# Altium schematic sheet Sheet3.SchDoc(Sheet3)
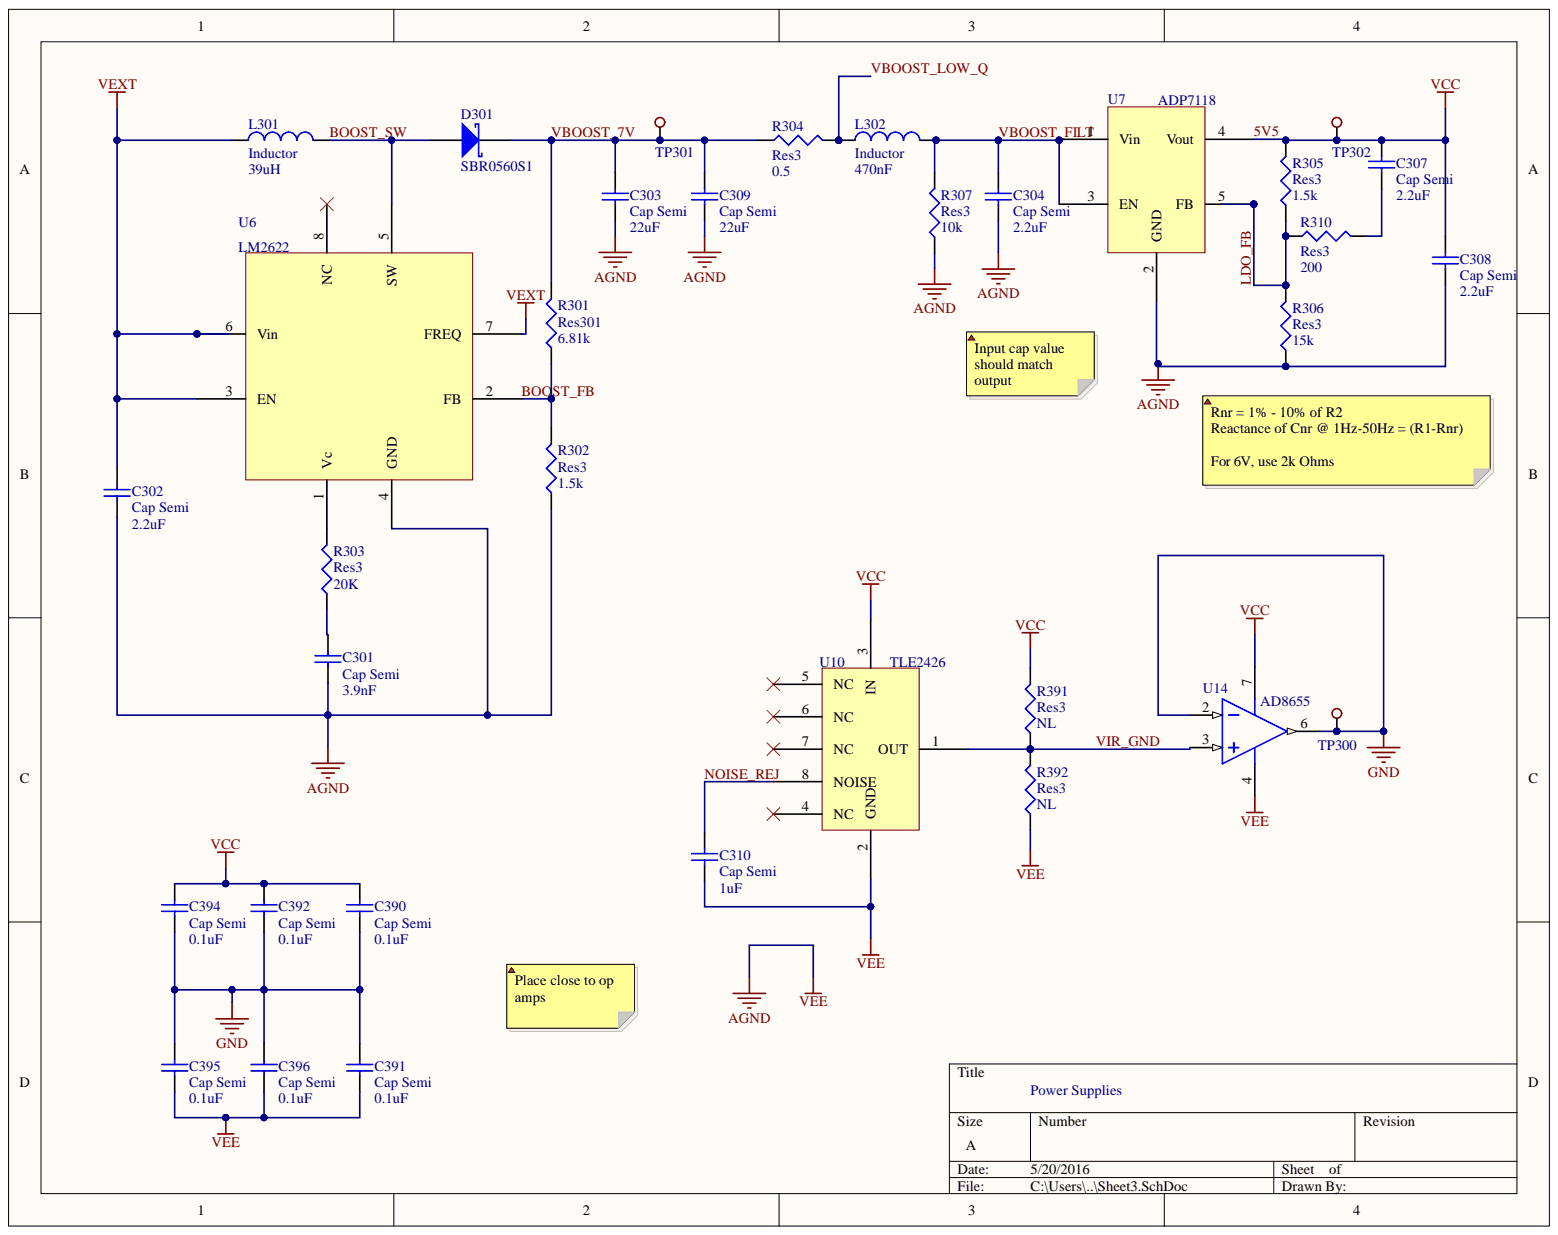
[diagram p0: Sheet3.SchDoc]

## Parts
C301  Cap Semi  value 3.9nF  [1608[0603]]
C302  Cap Semi  value 2.2uF  [1608[0603]]
C303  Cap Semi  value 22uF  [1608[0603]]
C304  Cap Semi  value 2.2uF  [1608[0603]]
C307  Cap Semi  value 2.2uF  [1608[0603]]
C308  Cap Semi  value 2.2uF  [1608[0603]]
C309  Cap Semi  value 22uF  [1608[0603]]
C310  Cap Semi  value 1uF  [1608[0603]]
C390  Cap Semi  value 0.1uF  [1608[0603]]
C391  Cap Semi  value 0.1uF  [1608[0603]]
C392  Cap Semi  value 0.1uF  [1608[0603]]
C394  Cap Semi  value 0.1uF  [1608[0603]]
C395  Cap Semi  value 0.1uF  [1608[0603]]
C396  Cap Semi  value 0.1uF  [1608[0603]]
D301  SBR0560S1  [SOD123]
L301  Inductor  value 39uH  [C1210_N]
L302  Inductor  value 470nF  [6-0805_M]
R301  Res301  value 6.81k  [J1-0603]
R302  Res3  value 1.5k  [J1-0603]
R303  Res3  value 20K  [J1-0603]
R304  Res3  value 0.5  [J1-0603]
R305  Res3  value 1.5k  [J1-0603]
R306  Res3  value 15k  [J1-0603]
R307  Res3  value 10k  [J1-0603]
R310  Res3  value 200  [J1-0603]
R391  Res3  value NL  [J1-0603]
R392  Res3  value NL  [J1-0603]
TP300  TEST_POINT  [TEST_POINT]  (value not drawn)
TP301  TEST_POINT  [TEST_POINT]  (value not drawn)
TP302  TEST_POINT  [TEST_POINT]  (value not drawn)
U6  LM2622  [VSSOP-8]
U7  ADP7118  [SOT23-5BN]
U10  TLE2426  [SO8_M]
U14  AD8655  [SO8_M]

## Nets
AGND: C301.1 C302.1 C303.1 C304.1 C308.1 C309.1 C310.1 C391.1 C395.1 C396.1 R302.1 R306.1 R307.1 R392.1 U6.4(GND) U7.2(GND) U10.2(GND) U14.4
GND: C390.1 C391.2 C392.1 C394.1 C395.2 C396.2 TP300.0 U14.2 U14.6
VEXT: C302.2 L301.1 U6.3(EN) U6.6(Vin) U6.7(FREQ)
5V5: C307.2 C308.2 C390.2 C392.2 C394.2 R305.2 R391.2 TP302.0 U7.4(Vout) U10.3(IN) U14.7
BOOST_FB: R301.1 R302.2 U6.2(FB)
BOOST_SW: D301.1 L301.2 U6.5(SW)
LDO_FB: R305.1 R306.2 R310.1 U7.5(FB)
NOISE_REJ: C310.2 U10.8(NOISE)
VBOOST_7V: C303.2 C309.2 D301.2 R301.2 R304.1 TP301.0
VBOOST_FILT: C304.2 L302.2 R307.2 U7.1(Vin) U7.3(EN)
VBOOST_LOW_Q: L302.1 R304.2
VIR_GND: R391.1 R392.2 U10.1(OUT) U14.3
NetC301_2: C301.2 R303.1
NetC307_1: C307.1 R310.2
NetR303_2: R303.2 U6.1(Vc)

Not connected: U6 8(NC); U10 4(NC) 5(NC) 6(NC) 7(NC)

## Library data of the parts
C301-C304 C307-C310 C390-C392 C394-C396 = Cap Semi: Capacitor (Semiconductor SIM Model); Component Kind: Standard; LatestRevisionDate: 29-May-2009; LatestRevisionNote: IPC-7351 Footprint Added.; Library Name: Miscellaneous Devices.IntLib; PackageDescription: Chip Capacitor; PackageReference: C1206; Published: 8-Jun-2000; Signal Integrity: Cap Semi; Simulation: CAP; Publisher: Altium Limited
D301 = Diode 11DQ03: Schottky Rectifier; Code_JEDEC: DO-204-AL; Component Kind: Standard; ComponentLink1Description: Manufacturer Link; ComponentLink1URL: http://www.irf.com/; ComponentLink2Description: Datasheet; ComponentLink2URL: http://www.irf.com/product-info/datasheets/data/11dq03.pdf; DatasheetVersion: Dec-1997; LatestRevisionDate: 17-Jul-2002; LatestRevisionNote: Re-released for DXP Platform.; Library Name: Miscellaneous Devices.IntLib; PackageDescription: Diode, Axial; Body 5.2 x 2.7 mm (LxDia. max), Lead Dia. 0.86 mm (max); PackageReference: DO-204AL; Published: 8-Jun-2000; Signal Integrity: Guessed Model; Simulation: 11DQ03; Publisher: Altium Limited
L301 = Inductor: LBC3225T330KR; Code_IEC: 1005; Code_JEITA: 0402; Component Kind: Standard; LatestRevisionDate: 29-May-2009; LatestRevisionNote: IPC-7351 Footprint Added.; Library Name: Miscellaneous Devices.IntLib; PackageDescription: Chip Inductor; PackageReference: 0402-A; Published: 8-Jun-2000; Signal Integrity: Inductor; Simulation: INDUCTOR; Publisher: Altium Limited
L302 = Inductor: Code_IEC: 1005; Code_JEITA: 0402; Component Kind: Standard; Description: Inductor; LatestRevisionDate: 29-May-2009; LatestRevisionNote: IPC-7351 Footprint Added.; Library Name: Miscellaneous Devices.IntLib; PackageDescription: Chip Inductor; PackageReference: 0402-A; Published: 8-Jun-2000; Signal Integrity: Inductor; Simulation: INDUCTOR; Publisher: Altium Limited
R301-R307 R391 R392 = Res3: Resistor; Component Kind: Standard; LatestRevisionDate: 29-May-2009; LatestRevisionNote: IPC-7351 Footprint Added.; Library Name: Miscellaneous Devices.IntLib; PackageDescription: Chip Resistor; PackageReference: J1-0603; Published: 8-Jun-2000; Signal Integrity: Res; Simulation: RESISTOR; Publisher: Altium Limited
R310 = Res3: Resistor; VALUE: 200; Component Kind: Standard; LatestRevisionDate: 29-May-2009; LatestRevisionNote: IPC-7351 Footprint Added.; Library Name: Miscellaneous Devices.IntLib; PackageDescription: Chip Resistor; PackageReference: J1-0603; Published: 8-Jun-2000; Signal Integrity: Res; Simulation: RESISTOR; Publisher: Altium Limited
U14 = AD8655: High Current OPAMP; Component Kind: Standard; Library Name: Altium.SchLib; Signal Integrity: Guessed Model
TP300-TP302 = TEST_POINT: Component Kind: Standard; Library Name: Altium.SchLib
U6 = LM2622: Component Kind: Standard; Library Name: Altium.SchLib; Signal Integrity: Guessed Model
U7 = ADP7118: Component Kind: Standard; Library Name: Altium.SchLib; Signal Integrity: Guessed Model
U10 = TLE2426: Component Kind: Standard; Library Name: Altium.SchLib; Signal Integrity: Guessed Model
